# Altium schematic sheet 原理图.SchDoc
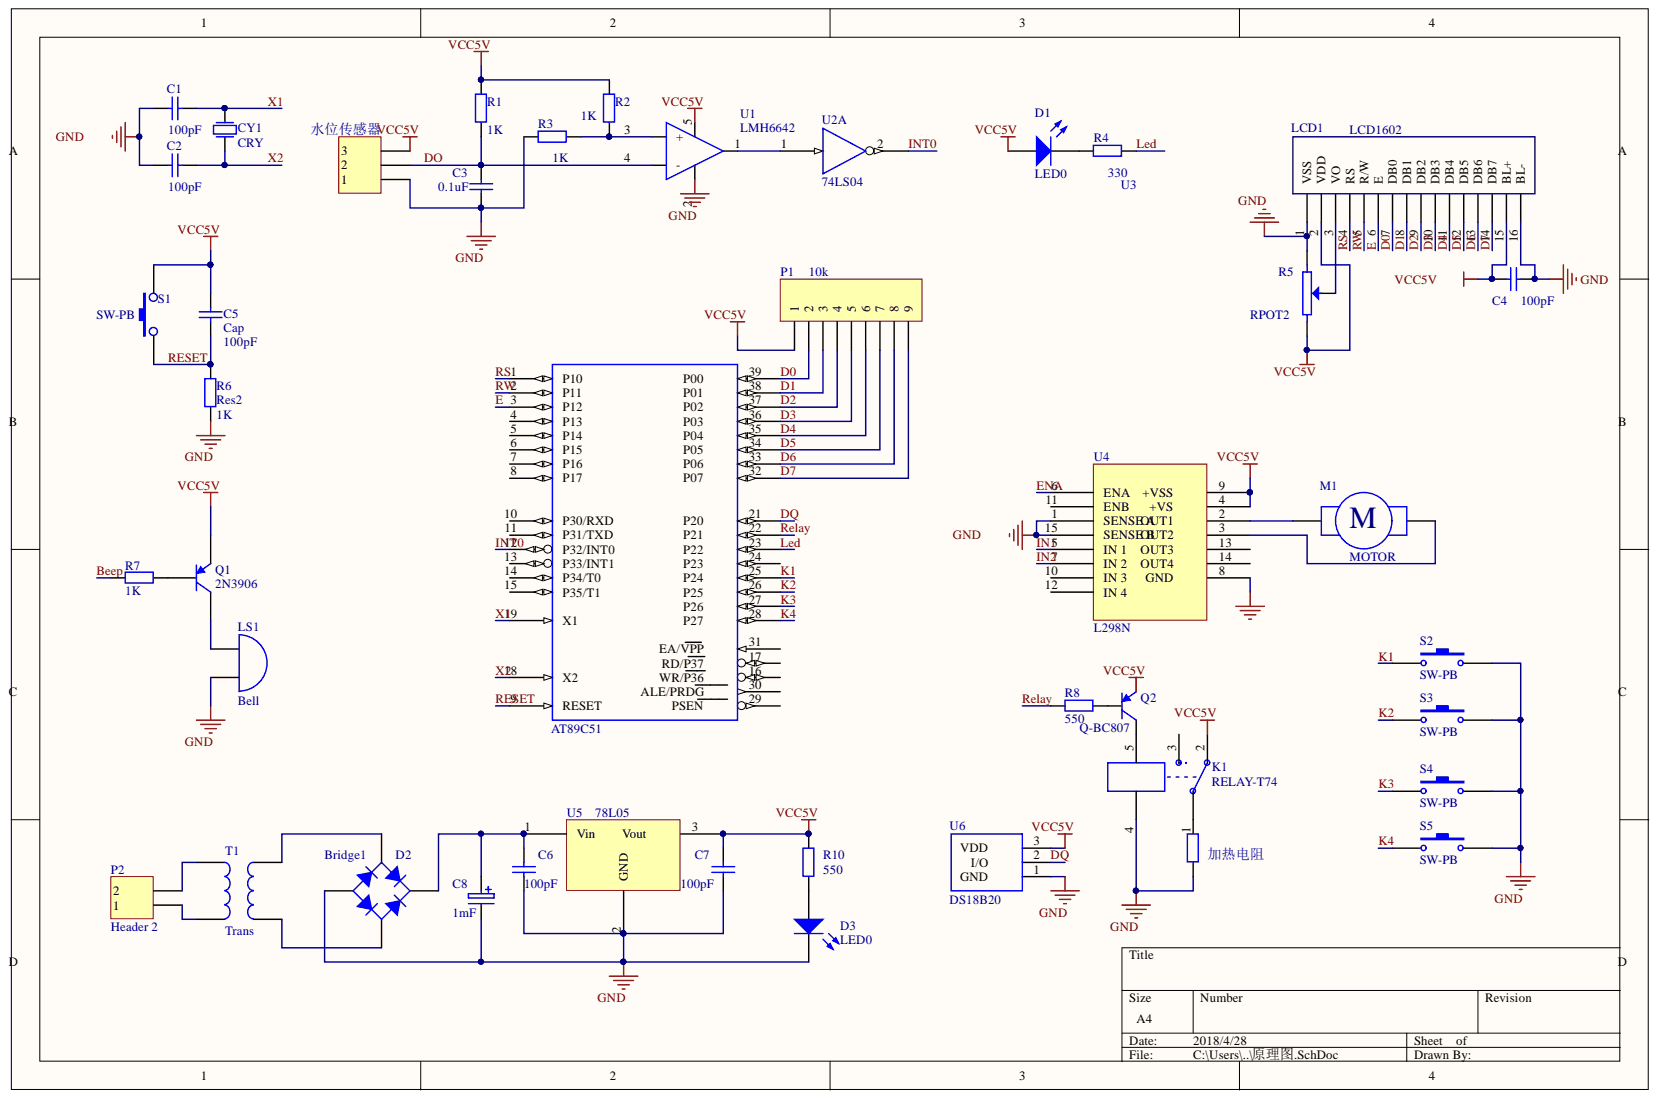
[diagram p0: 原理图.SchDoc]

## Parts
C1  value 100pF  [RAD-0.3]  (hidden comment: Cap)
C2  value 100pF  [RAD-0.3]  (hidden comment: Cap)
C3  value 0.1uF  [RAD-0.3]  (hidden comment: Cap)
C4  value 100pF  [RAD-0.3]  (hidden comment: Cap)
C5  Cap  value 100pF  [RAD-0.3]
C6  value 100pF  [RAD-0.3]  (hidden comment: Cap)
C7  value 100pF  [RAD-0.3]  (hidden comment: Cap)
C8  1mF  [RB1]
CY1  CRY  [XTAL-1]
D1  LED0  [LED-0]
D2  Bridge1  [D-38]
D3  LED0  [LED-0]
K1  RELAY-T74  [RELAY-T74]
LCD1  LCD1602  [LCD1602]
LS1  Bell  [PIN2]
M1  MOTOR  [DG1282]
P1  10k  [MHDR1X9]
P2  Header 2  [HDR1X2]
Q1  2N3906  [TO-92A]
Q2  Q-BC807  [SOT-23Q]
R1  value 1K  [AXIAL-0.4]  (hidden comment: Res2)
R2  value 1K  [AXIAL-0.4]  (hidden comment: Res2)
R3  value 1K  [AXIAL-0.4]  (hidden comment: Res2)
R4  value 330  [AXIAL-0.4]  (hidden comment: Res2)
R5  RPOT2  [VR5]
R6  Res2  value 1K  [AXIAL-0.4]
R7  value 1K  [AXIAL-0.4]  (hidden comment: Res2)
R8  value 550  [AXIAL-0.4]  (hidden comment: Res2)
R10  value 550  [AXIAL-0.4]  (hidden comment: Res2)
S1  SW-PB
S2  SW-PB  [SPST-2]
S3  SW-PB  [SPST-2]
S4  SW-PB  [SPST-2]
S5  SW-PB  [SPST-2]
T1  Trans  [TRANS]
U1  LMH6642
U2  74LS04  [DIP-14]  (part A)
U3  AT89C51  [DIP-40]
U4  L298N  [L298N散热片]
U5  78L05  [TO257V]
U6  DS18B20  [TO-92A]
加热电阻  Res2  [AXIAL-0.4]  (value not drawn)
水位传感器  Header 3H  [HDR1X3H]  (value not drawn)

## Nets
GND: C1.1 C2.1 C3.1 C4.1 C6.1 C7.1 C8.2 D2.3 D3.2 K1.4 LCD1.1(VSS) LCD1.16(BL-) LS1.2 R3.2 R5.3 R6.1 S2.2 S3.2 S4.2 S5.2 U1.2 U2.7 U3.20 U4.1(SENSE) U4.8 U4.15(SENSE) U5.2 U6.1 加热电阻.1 水位传感器.1
VCC: U2.14 U3.40
VCC5V: C4.2 C5.2 C7.2 D1.1 K1.2 LCD1.2(VDD) LCD1.15(BL+) P1.1 Q1.1 R1.2 R2.2 R5.1 R10.2 S1.2 U1.5 U4.4 U4.9 U5.3 U6.3 水位传感器.3
BEEP: R7.2
D0: LCD1.7(DB0) P1.2 U3.39
D1: LCD1.8(DB1) P1.3 U3.38
D2: LCD1.9(DB2) P1.4 U3.37
D3: LCD1.10(DB3) P1.5 U3.36
D4: LCD1.11(DB4) P1.6 U3.35
D5: LCD1.12(DB5) P1.7 U3.34
D6: LCD1.13(DB6) P1.8 U3.33
D7: LCD1.14(DB7) P1.9 U3.32
DO: C3.2 R1.1 U1.4(-) 水位传感器.2
DQ: U3.21 U6.2
E: LCD1.6(E) U3.3(P12)
ENA: U4.6(ENA)
IN1: U4.5(IN_1)
IN2: U4.7(IN_2)
INT0: U2.2 U3.12(P32/INT0)
K1: S2.1 U3.25
K2: S3.1 U3.26
K3: S4.1 U3.27
K4: S5.1 U3.28
LED: R4.1 U3.23
RELAY: R8.2 U3.22
RESET: C5.1 R6.2 S1.1 U3.9(RESET)
RS: LCD1.4(RS) U3.1(P10)
RW: LCD1.5(R/W) U3.2(P11)
X1: C1.2 CY1.1 U3.19(X1)
X2: C2.2 CY1.2 U3.18(X2)
NetC6_2: C6.2 C8.1 D2.1 U5.1
NetD1_2: D1.2 R4.2
NetD2_2: D2.2 T1.4
NetD2_4: D2.4 T1.3
NetD3_1: D3.1 R10.1
NetK1_1: K1.1 加热电阻.2
NetK1_5: K1.5 Q2.C
NetLCD1_3: LCD1.3(VO) R5.2
NetLS1_1: LS1.1 Q1.3
NetM1_1: M1.1 U4.3
NetM1_2: M1.2 U4.2
NetP2_1: P2.1 T1.2
NetP2_2: P2.2 T1.1
NetQ1_2: Q1.2 R7.1
NetQ2_B: Q2.B R8.1
NetR2_1: R2.1 R3.1 U1.3(+)
NetU1_1: U1.1 U2.1

Not connected: K1 3; Q2 E; U3 4(P13) 5(P14) 6(P15) 7(P16) 8(P17) 10(P30/RXD) 11(P31/TXD) 13(P33/INT1) 14(P34/T0) 15(P35/T1) 16 17 24 29 30 31; U4 10(IN_3) 11(ENB) 12(IN_4) 13 14

## Library data of the parts
C1-C7 = Cap: Capacitor; Component Kind: Standard; LatestRevisionDate: 17-Jul-2002; LatestRevisionNote: Re-released for DXP Platform.; Library Name: Miscellaneous Devices.IntLib; PackageDescription: Radial Cap, Thru-Hole; 2 Leads; 0.3 in Pin Spacing; PackageReference: RAD-0.3; Published: 8-Jun-2000; Simulation: CAP; Publisher: Altium Limited
C8 = CAP3: Component Kind: Standard; Library Name: C电容.SchLib
D1 D3 = LED0: Typical INFRARED GaAs LED; Component Kind: Standard; LatestRevisionDate: 08-Jul-2005; LatestRevisionNote: Mech Layer 1 removed.; Library Name: Miscellaneous Devices.IntLib; PackageDescription: LED; 2 Leads; PackageReference: LED-0; Published: 8-Jun-2000; Simulation: LED0; Publisher: Altium Limited
D2 = Bridge1: Full Wave Diode Bridge; Component Kind: Standard; DatasheetVersion: E2732B; LatestRevisionDate: 29-May-2009; LatestRevisionNote: Sch pins A & K swapped.; Library Name: Miscellaneous Devices.IntLib; PackageDescription: Bridge Rectifier; 4 Terminals; Body 9.4 x 9.4 mm (typ); Pitch 5.08 mm; PackageReference: D-38; Published: 8-Jun-2000; Simulation: BRIDGE; Publisher: Altium Limited
K1 = RELAY-T74: CN-RELAY-T74; Component Kind: Standard; Library Name: 常用器件.SCHLIB
LS1 = Bell: Electrical Bell; Component Kind: Standard; LatestRevisionDate: 17-Jul-2002; LatestRevisionNote: Re-released for DXP Platform.; Library Name: Miscellaneous Devices.IntLib; PackageReference: PIN2; Published: 24-Mar-1999; Publisher: Altium Limited
P1 = MHDR1X9: Header, 9-Pin; Component Kind: Standard; LatestRevisionDate: 17-Jul-2002; LatestRevisionNote: Re-released for DXP Platform.; Library Name: Miscellaneous Connectors.IntLib; Publisher: Altium Limited
P2 = Header 2: Header, 2-Pin; Component Kind: Standard; LatestRevisionDate: 17-Jul-2002; LatestRevisionNote: Re-released for DXP Platform.; Library Name: Miscellaneous Connectors.IntLib; Publisher: Altium Limited
Q1 = 2N3906: PNP General Purpose Amplifier; Component Kind: Standard; ComponentLink1Description: Manufacturer Link; ComponentLink1URL: http://www.fairchildsemi.com/; ComponentLink2Description: Datasheet; ComponentLink2URL: http://www.fairchildsemi.com/ds/2N%2F2N3906.pdf; DatasheetVersion: 1997; LatestRevisionDate: 15-Jan-2003; LatestRevisionNote: PCB Footprint 'BCY-W3/D4.7' replaced; Library Name: Miscellaneous Devices.IntLib; PackageDescription: TO, Flat Index; 3 In-Line, Axial Leads; Body Dia. 4.5mm; Leads 0.51 x 0.48 mm (max); PackageReference: TO-92A; PackageVersion: Sep-1998; Published: 8-Jun-2000; Simulation: 2N3906; Publisher: Altium Limited
Q2 = Q-BC807: PNP General Purpose Amplifier; Code_JEDEC: TO-92A; Component Kind: Standard; ComponentLink1Description: Manufacturer Link; ComponentLink1URL: http://www.fairchildsemi.com/; ComponentLink2Description: Datasheet; ComponentLink2URL: http://www.fairchildsemi.com/ds/2N%2F2N3906.pdf; DatasheetDocument: 1997; LatestRevisionDate: 15-Jan-2003; LatestRevisionNote: PCB Footprint 'BCY-W3/D4.7' replaced; PackageDocument: Sep-1998; PackageReference: TO-92A; Published: 8-Jun-2000; Simulation: 2N3906; Library Name: 常用器件.SCHLIB; Publisher: Altium Limited
R1-R3 R6-R8 R10 = Res2: Resistor; Component Kind: Standard; LatestRevisionDate: 17-Jul-2002; LatestRevisionNote: Re-released for DXP Platform.; Library Name: Miscellaneous Devices.IntLib; PackageDescription: Axial Device, Thru-Hole; 2 Leads; 0.4 in Pin Spacing; PackageReference: AXIAL-0.4; Published: 8-Jun-2000; Simulation: RESISTOR; Publisher: Altium Limited
R4 = Res2: Resistor; Value: 330; Component Kind: Standard; LatestRevisionDate: 17-Jul-2002; LatestRevisionNote: Re-released for DXP Platform.; Library Name: Miscellaneous Devices.IntLib; PackageDescription: Axial Device, Thru-Hole; 2 Leads; 0.4 in Pin Spacing; PackageReference: AXIAL-0.4; Published: 8-Jun-2000; Simulation: RESISTOR; Publisher: Altium Limited
R5 = RPOT2: Potentiometer; Component Kind: Standard; Library Name: 电阻.SchLib
S2-S5 = SW-PB: Switch; Component Kind: Standard; LatestRevisionDate: 17-Jul-2002; LatestRevisionNote: Re-released for DXP Platform.; PackageReference: SPST-2; Published: 24-Mar-1999; Library Name: 常用器件.SCHLIB; Publisher: Altium Limited
T1 = Trans: Transformer; Component Kind: Standard; LatestRevisionDate: 08-Jul-2005; LatestRevisionNote: Mech Layer 1 removed.; Library Name: Miscellaneous Devices.IntLib; PackageDescription: Transformer; 4 Leads; PackageReference: TRANS; Published: 24-Mar-1999; Simulation: TRANSFORMER; Publisher: Altium Limited
U1 = LMH6642: Amplifier IC; Component Kind: Standard; Library Name: 常用器件.SCHLIB
U5 = 78**: Component Kind: Standard; Library Name: 稳压管.SchLib
加热电阻 = Res2: Resistor; Value: 550; Component Kind: Standard; LatestRevisionDate: 17-Jul-2002; LatestRevisionNote: Re-released for DXP Platform.; Library Name: Miscellaneous Devices.IntLib; PackageDescription: Axial Device, Thru-Hole; 2 Leads; 0.4 in Pin Spacing; PackageReference: AXIAL-0.4; Published: 8-Jun-2000; Simulation: RESISTOR; Publisher: Altium Limited
水位传感器 = Header 3H: Header, 3-Pin, Right Angle; Component Kind: Standard; LatestRevisionDate: 17-Jul-2002; LatestRevisionNote: Re-released for DXP Platform.; Library Name: Miscellaneous Connectors.IntLib; Publisher: Altium Limited
CY1 = CRY: Component Kind: Standard; Library Name: CRY晶振.SchLib; Simulation: CRY
LCD1 = LCD1602: Component Kind: Standard; Library Name: LCD1602.IntLib
M1 = MOTOR: Component Kind: Standard; Library Name: 单片机及相关.SCHLIB
S1 = SW-PB: Component Kind: Standard; Library Name: SW开关.SchLib
U2 = 74LS04: Component Kind: Standard; Library Name: 74xxTTL.SchLib
U3 = AT89C51: Component Kind: Standard; Library Name: 单片机及相关.SCHLIB
U4 = L298N: Component Kind: Standard; Library Name: KU1.SchLib
U6 = DS18B20: Component Kind: Standard; Library Name: 单片机及相关.SCHLIB
